# Altium schematic sheet Motor_Brake.SchDoc
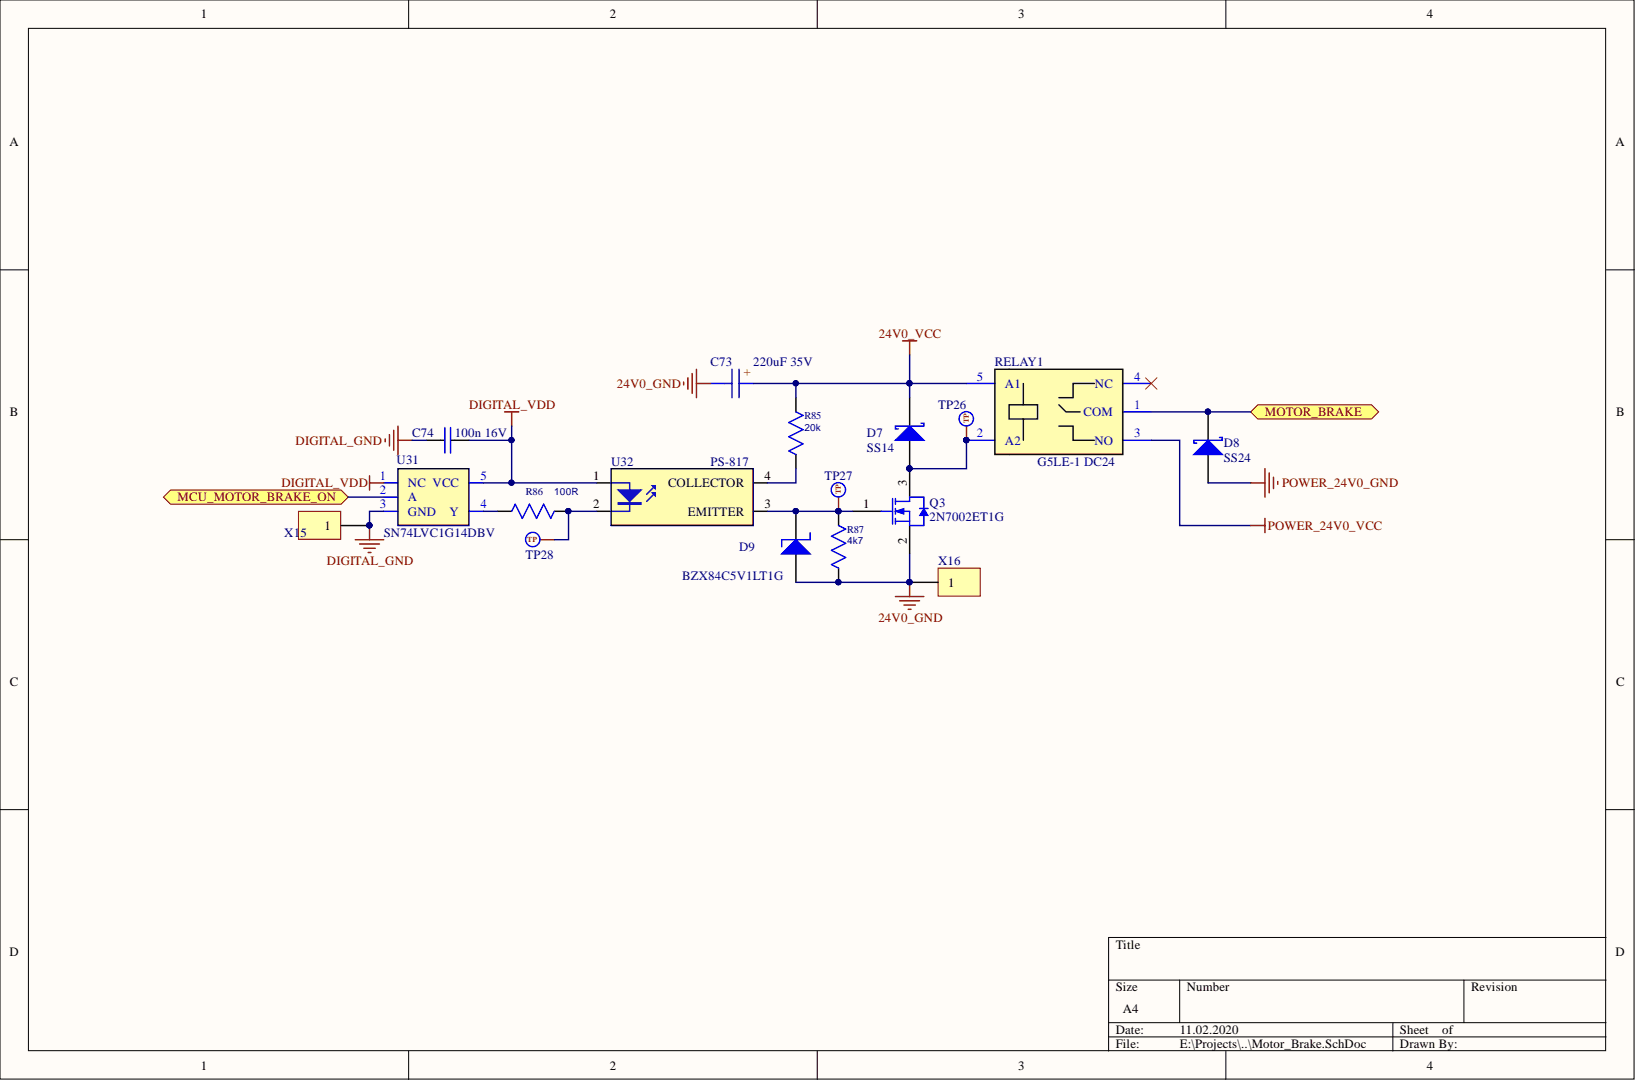
[diagram p0: Motor_Brake.SchDoc]

## Parts
C73  220uF 35V  [C_8x11.5x3.5]
C74  100n 16V  [C_0805]
D7  SS14  [SMA]
D8  SS24  [SOD123F]
D9  BZX84C5V1LT1G  [SOT23-3]
Q3  2N7002ET1G  [SOT23-3]
R85  20k  [R_0805]
R86  100R  [R_0805]
R87  4k7  [R_0805]
RELAY1  G5LE-1 DC24  [G5LE-1]
TP26  TEST_POINT  [TEST POINT D1.5]  (value not drawn)
TP27  TEST_POINT  [TEST POINT D1.5]  (value not drawn)
TP28  TEST_POINT  [TEST POINT D1.5]  (value not drawn)
U31  SN74LVC1G14DBV  [SOT23-5]
U32  PS-817  [PS817_SO]
X15  PLS-1  [PLS-1-3D]  (value not drawn)
X16  PLS-1  [PLS-1-3D]  (value not drawn)

## Ports
MCU_MOTOR_BRAKE_ON, MOTOR_BRAKE

## Nets
24V0_GND: C73.2 D9.1 Q3.2 R87.1 X16.1
24V0_VCC: C73.1 D7.2 R85.2 RELAY1.5(A1)
DIGITAL_GND: C74.1 U31.3(GND) X15.1
DIGITAL_VDD: C74.2 U31.1(NC) U31.5(VCC) U32.1
POWER_24V0_GND: D8.1
POWER_24V0_VCC: RELAY1.3(NO)
MCU_MOTOR_BRAKE_ON: U31.2(A) ; port MCU_MOTOR_BRAKE_ON
MOTOR_BRAKE: D8.2 RELAY1.1(COM) ; port MOTOR_BRAKE
NetD7_1: D7.1 Q3.3 RELAY1.2(A2) TP26.1
NetD9_3: D9.3 Q3.1 R87.2 TP27.1 U32.3(EMITTER)
NetR85_1: R85.1 U32.4(COLLECTOR)
NetR86_1: R86.1 U31.4(Y)
NetR86_2: R86.2 TP28.1 U32.2

Not connected: RELAY1 4(NC)

## Library data of the parts
C73 = WL_SIZE_8x11.5x3.5: Aluminum Electrolytic Capacitors; Component Kind: Standard; Library Name: Capacitors.SchLib; Value: 220uF 35V
C74 = Cap NP: CERAMIC CAPACITOR; Component Kind: Standard; Library Name: Capacitors.SchLib; Value: 100n 16V
D7 = D Schottky: Schottky Diode; Code_JEDEC: DO-214-AA; Component Kind: Standard; LatestRevisionDate: 17-Jul-2002; LatestRevisionNote: Re-released for DXP Platform.; Library Name: Diode.SchLib; PackageDescription: DO-214-AA/SMB; 2 C-Bend Leads; Body 5.3 x 3.6 mm, inc. leads (LxW); PackageReference: SMB; Published: 8-Jun-2000; Signal Integrity: D Schottky; Simulation: SKYDIODE; Publisher: Altium Limited
D8 = SS24: Schottky Diode; Component Kind: Standard; Library Name: Diode.SchLib; Value: SS24
D9 = BZX84CxxxLT1G: Zener Voltage Regulators 250mW SOT-23; Component Kind: Standard; Library Name: Diode.SchLib; Value: BZX84C5V1LT1G
Q3 = 2N7002ET1G: Small Signal MOSFET, 60 V, 310 mA, Single, N-Channel, 3-Pin SOT-23, Pb-Free, Tape and Reel; Component Kind: Standard; Library Name: Transistors.SchLib; Value: 2N7002ET1G
R85-R87 = R0805: Resistor; Component Kind: Standard; Library Name: Resistors.SchLib
  R85: Value: 20k
  R86: Value: 100R
  R87: Value: 4k7
RELAY1 = G5LE-1: Cubic, Single-pole 10A Power  Relay; Component Kind: Standard; Library Name: Relay.SchLib; Value: G5LE-1 DC24
TP26-TP28 = TEST_POINT: Signal Test Point; Component Kind: Standard; Library Name: Discrete.SchLib
U31 = SN74LVC1G14DBV: Syngle Inverter Schmitt Gate SOT23-5; Component Kind: Standard; Value: SN74LVC1G14DBV; Library Name: Gates.SchLib
U32 = PS-817: DIP and SMD Package; Component Kind: Standard; Library Name: Isolator.SchLib
X15 X16 = PLS-1: Header, 1-Pin; Component Kind: Standard; LatestRevisionDate: 17-Jul-2002; LatestRevisionNote: Re-released for DXP Platform.; Library Name: Connectors.SchLib; Publisher: Altium Limited
